# Altium schematic sheet Flash(EMMC).SchDoc
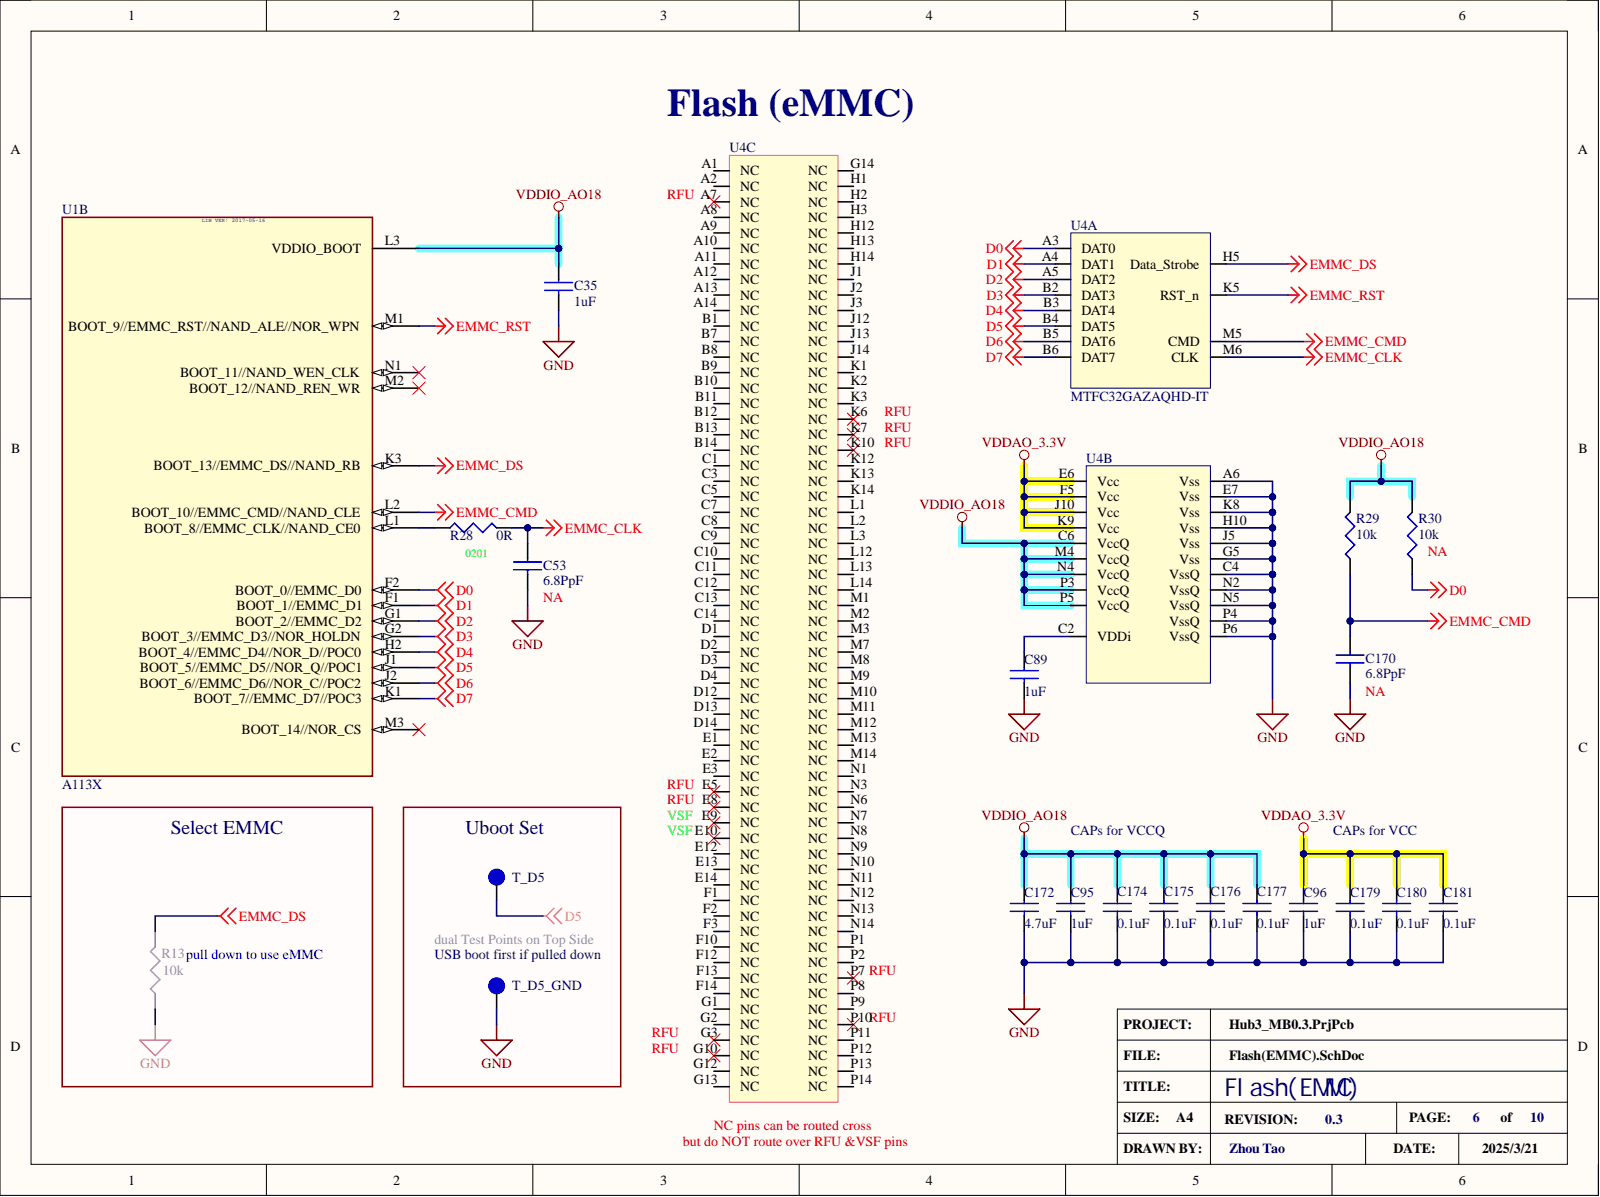
[diagram p0: Flash(EMMC).SchDoc]

## Parts
C35  1uF  [C0201]
C53  NA  value 6.8PpF  [C0201]  (no BOM)
C89  1uF  [C0201]
C95  1uF  [C0201]
C96  1uF  [C0201]
C170  NA  value 6.8PpF  [C0201]  (no BOM)
C172  4.7uF  [C0603]
C174  0.1uF  [C0201]
C175  0.1uF  [C0201]
C176  0.1uF  [C0201]
C177  0.1uF  [C0201]
C179  0.1uF  [C0201]
C180  0.1uF  [C0201]
C181  0.1uF  [C0201]
R13  10k  [R0402]
R28  0R  [R0201]
R29  10k  [R0201]
R30  NA  value 10k  [R0201]  (no BOM)
T_D5  T_POINT_D0.6mm  [TP30]  (value not drawn)  (no BOM)
T_D5_GND  T_POINT_D0.6mm  [TP30]  (value not drawn)  (no BOM)
U1  A113X  [A113X_284]  (part B)
U4  MTFC32GAZAQHD-IT  [EMMC_KIOXIA]  (parts A,B,C)

## Nets
D0: R30.1 U1.F2(BOOT_0//EMMC_D0) U4.A3(DAT0)
D1: U1.F1(BOOT_1//EMMC_D1) U4.A4(DAT1)
D2: U1.G1(BOOT_2//EMMC_D2) U4.A5(DAT2)
D3: U1.G2(BOOT_3//EMMC_D3//NOR_HOLDN) U4.B2(DAT3)
D4: U1.H2(BOOT_4//EMMC_D4//NOR_D//POC0) U4.B3(DAT4)
D5: T_D5.1 U1.J1(BOOT_5//EMMC_D5//NOR_Q//POC1) U4.B4(DAT5)
D6: U1.J2(BOOT_6//EMMC_D6//NOR_C//POC2) U4.B5(DAT6)
D7: U1.K1(BOOT_7//EMMC_D7//POC3) U4.B6(DAT7)
EMMC_CLK: C53.1 R28.2 U4.M6(CLK)
EMMC_CMD: C170.1 R29.1 U1.L2(BOOT_10//EMMC_CMD//NAND_CLE) U4.M5(CMD)
EMMC_DS: R13.2 U1.K3(BOOT_13//EMMC_DS//NAND_RB) U4.H5(Data_Strobe)
EMMC_RST: U1.M1(BOOT_9//EMMC_RST//NAND_ALE//NOR_WPN) U4.K5(RST_n)
GND: C35.2 C53.2 C89.2 C95.2 C96.2 C170.2 C172.2 C174.2 C175.2 C176.2 C177.2 C179.2 C180.2 C181.2 R13.1 T_D5_GND.1 U4.A6(Vss) U4.C4(VssQ) U4.E7(Vss) U4.G5(Vss) U4.H10(Vss) U4.J5(Vss) U4.K8(Vss) U4.N2(VssQ) U4.N5(VssQ) U4.P4(VssQ) U4.P6(VssQ)
VDDAO_3.3V: C96.1 C179.1 C180.1 C181.1 U4.E6(Vcc) U4.F5(Vcc) U4.J10(Vcc) U4.K9(Vcc)
VDDIO_AO18: C35.1 C95.1 C172.1 C174.1 C175.1 C176.1 C177.1 R29.2 R30.2 U1.L3(VDDIO_BOOT) U4.C6(VccQ) U4.M4(VccQ) U4.N4(VccQ) U4.P3(VccQ) U4.P5(VccQ)
NetC89_1: C89.1 U4.C2(VDDi)
NetR28_1: R28.1 U1.L1(BOOT_8//EMMC_CLK//NAND_CE0)

Not connected: U1 M2(BOOT_12//NAND_REN_WR) M3(BOOT_14//NOR_CS) N1(BOOT_11//NAND_WEN_CLK); U4 A1(NC) A2(NC) A7(NC) A8(NC) A9(NC) A10(NC) A11(NC) A12(NC) A13(NC) A14(NC) B1(NC) B7(NC) B8(NC) B9(NC) B10(NC) B11(NC) B12(NC) B13(NC) B14(NC) C1(NC) C3(NC) C5(NC) C7(NC) C8(NC) C9(NC) C10(NC) C11(NC) C12(NC) C13(NC) C14(NC) D1(NC) D2(NC) D3(NC) D4(NC) D12(NC) D13(NC) D14(NC) E1(NC) E2(NC) E3(NC) E5(NC) E8(NC) E9(NC) E10(NC) E12(NC) E13(NC) E14(NC) F1(NC) F2(NC) F3(NC) F10(NC) F12(NC) F13(NC) F14(NC) G1(NC) G2(NC) G3(NC) G10(NC) G12(NC) G13(NC) G14(NC) H1(NC) H2(NC) H3(NC) H12(NC) H13(NC) H14(NC) J1(NC) J2(NC) J3(NC) J12(NC) J13(NC) J14(NC) K1(NC) K2(NC) K3(NC) K6(NC) K7(NC) K10(NC) K12(NC) K13(NC) K14(NC) L1(NC) L2(NC) L3(NC) L12(NC) L13(NC) L14(NC) M1(NC) M2(NC) M3(NC) M7(NC) M8(NC) M9(NC) M10(NC) M11(NC) M12(NC) M13(NC) M14(NC) N1(NC) N3(NC) N6(NC) N7(NC) N8(NC) N9(NC) N10(NC) N11(NC) N12(NC) N13(NC) N14(NC) P1(NC) P2(NC) P7(NC) P8(NC) P9(NC) P10(NC) P11(NC) P12(NC) P13(NC) P14(NC)

## Library data of the parts
C35 C89 C95 C96 = Cap_1uF_0201_6.3V: Manufacturer: Walsin Tech; Part Number: 0201X105K6R3CT; Des: 1uF,0201,6.3V,10%,X5R; Component Kind: Standard; value: 1uF; Library Name: Lib_sch_Hubv3_2332.SchLib
C53 C170 = Cap_6.8pF_0201_50V: Manufacturer: EYANG; Part Number: C0201C0G6R8B500NTA; Des: 6.8pF+/-0.1pF,0201,50V,C0G; Library Name: Lib_sch_Hubv3_2332.SchLib
C172 = Cap_4.7uF_0603_X5R_10%_10V: Manufacturer: Yageo; Part Number: CC0603KRX5R6BB475; Des: 4.7uF,C0603, 10V, X5R,10%; Component Kind: Standard; value: 4.7uF; Library Name: Lib_sch_Hubv3_2332.SchLib
C174-C177 C179-C181 = Cap_0.1uF_0201_16V: Manufacturer: EYANG; Part Number: C0201X5R104K160NTA; Des: 0.1uF,0201,16V,X5R,10%; Component Kind: Standard; value: 0.1uF; Library Name: Lib_sch_Hubv3_2332.SchLib
R13 = RES_10k_0402_1%: Manufacturer: Yageo; part number: RC0402FR-0710KL; Des: 10k,0402,1%; Component Kind: Standard; value: 10k; Library Name: Lib_sch_spk_V0_190722.SchLib
R28 = RES_0R_0201: Manufacturer: Yageo; part number: RC0201JR-070RL; Des: 0R,0201,1/20w; Component Kind: Standard; value: 0R; Library Name: Lib_sch_Hubv3_2332.SchLib
R29 R30 = RES_10k_0201_1%: Manufacturer: Yageo; part number: RC0201FR-0710KL; Des: 10k,0201,1%; Library Name: Lib_sch_spk_V1_2020604.SchLib
  R29: Component Kind: Standard; value: 10k
T_D5 T_D5_GND = T_POINT_D0.6mm: Des: circle test pad (Dia=0.6mm ); Library Name: Lib_sch_spk_V0_190722.SchLib
U1 = A113X_20170516_V17: Manufacturer: AmLogic; Des: A113X, BGA,V17; Component Kind: Standard; Part Number: A113X; value: A113X; Library Name: Lib_sch_Hubv3_2332.SchLib
U4 = EMMC_153FBGA_32GB_5.1: Manufacturer: Micron; Des: 32GB,153 ball FBGA, emmc5.1; Component Kind: Standard; Part Number: MTFC32GAZAQHD-IT; value: MTFC32GAZAQHD-IT; Library Name: Lib_sch_Hubv3_2332.SchLib
